# Altium schematic sheet ICE40UP5K base design.SchDoc
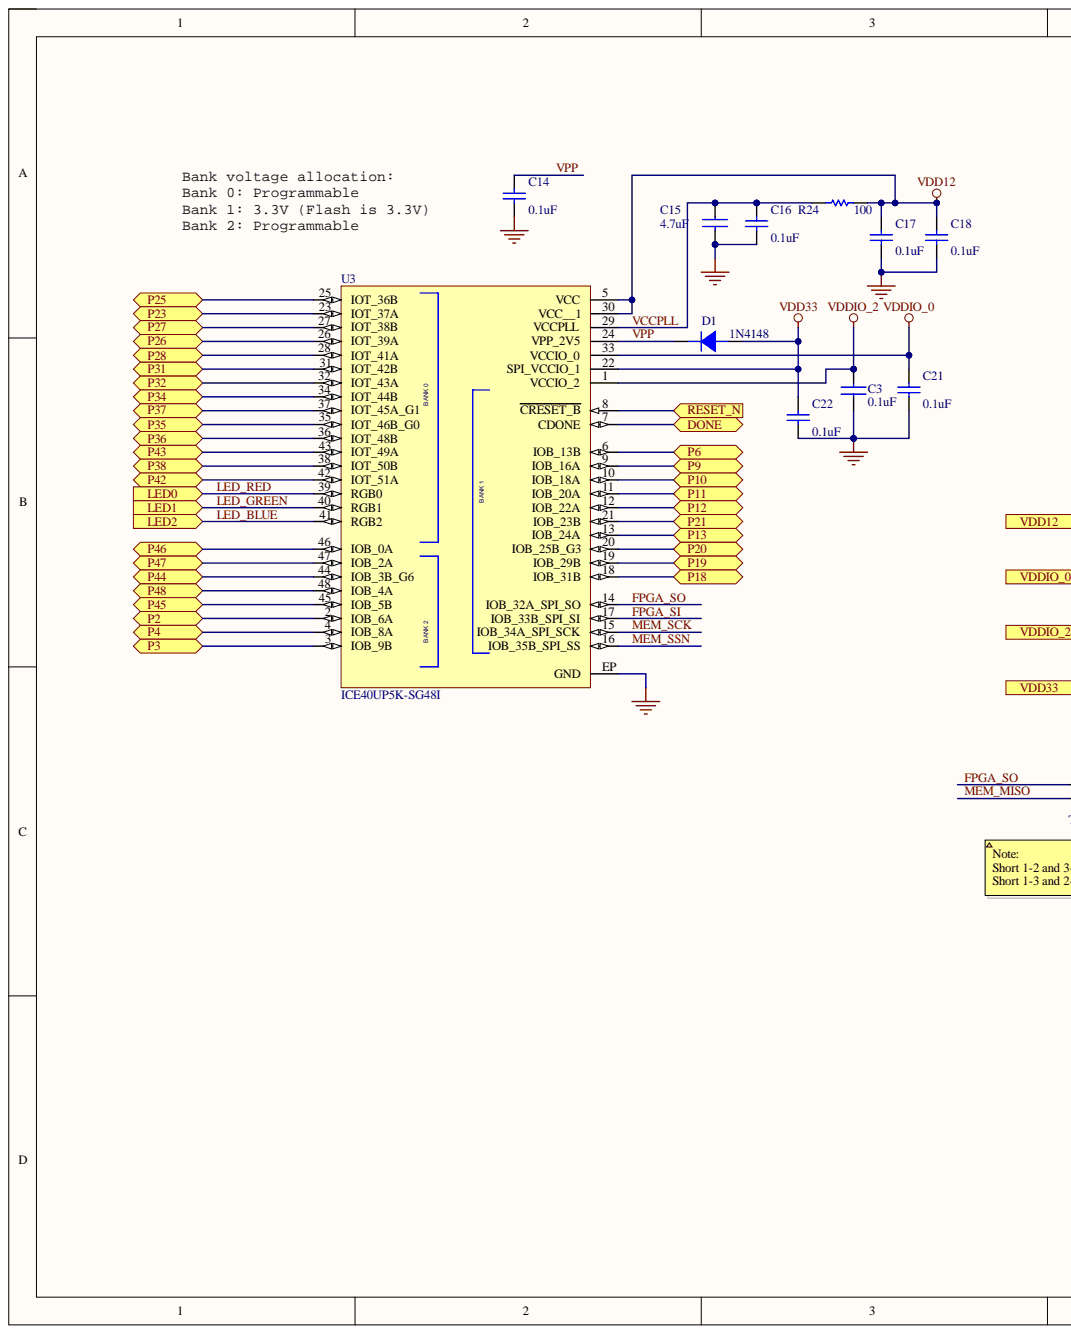
[diagram p0: ICE40UP5K base design.SchDoc, left]
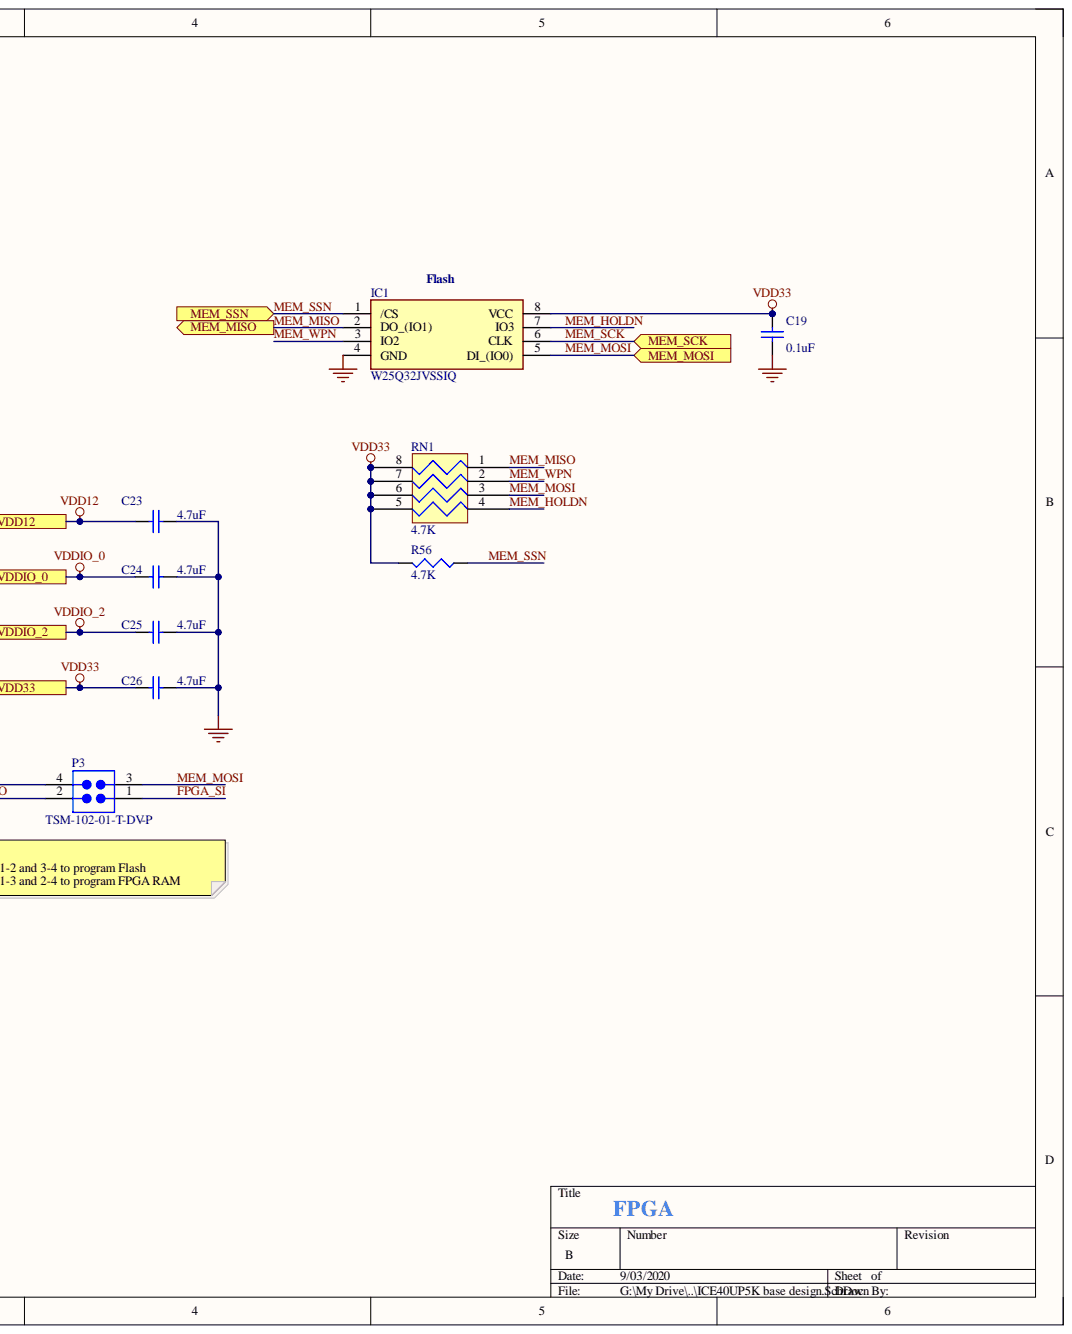
[diagram p1: ICE40UP5K base design.SchDoc, right]

## Parts
C3  0.1uF  [0402]
C14  0.1uF  [C0603]
C15  4.7uF  [C0603]
C16  0.1uF  [C0603]
C17  0.1uF  [C0603]
C18  0.1uF  [C0603]
C19  0.1uF  [C0603]
C21  0.1uF  [C0603]
C22  0.1uF  [C0603]
C23  4.7uF  [C0603]
C24  4.7uF  [C0603]
C25  4.7uF  [C0603]
C26  4.7uF  [C0603]
D1  1N4148  [SOD-523F]
IC1  W25Q32JVSSIQ  [SOIC-8-200MIL]
P3  TSM-102-01-T-DV-P  [TSM-102-01-T-DV-P-TR]
R24  100  [R0603]
R56  4.7K  [R0603]
RN1  4.7K  [RESCAXS50P100X200X45-8NA]
U3  ICE40UP5K-SG48I  [QFN-48-1EP_7x7mm_P0.5mm_EP5.6x5.6mm]

## Ports
DONE, LED0, LED1, LED2, MEM_MISO, MEM_MOSI, MEM_SCK, MEM_SSN, P2, P3, P4, P6, P9, P10, P11, P12, P13, P18, P19, P20, P21, P23, P25, P26, P27, P28, P31, P32, P34, P35, P36, P37, P38, P42, P43, P44, P45, P46, P47, P48, RESET_N, VDD12, VDD33, VDDIO_0, VDDIO_2

## Nets
GND: C3.1 C14.2 C15.2 C16.2 C17.2 C18.2 C19.2 C21.2 C22.2 C23.1 C24.1 C25.1 C26.1 IC1.4(GND) U3.EP(GND)
DONE: U3.7(CDONE) ; port DONE
FPGA_SI: P3.1 U3.17(IOB_33B_SPI_SI)
FPGA_SO: P3.4 U3.14(IOB_32A_SPI_SO)
LED_BLUE: U3.41(RGB2) ; port LED2
LED_GREEN: U3.40(RGB1) ; port LED1
LED_RED: U3.39(RGB0) ; port LED0
MEM_HOLDN: IC1.7(IO3) RN1.4
MEM_MISO: IC1.2(DO_(IO1)) P3.2 RN1.1 ; port MEM_MISO
MEM_MOSI: IC1.5(DI_(IO0)) P3.3 RN1.3 ; port MEM_MOSI
MEM_SCK: IC1.6(CLK) U3.15(IOB_34A_SPI_SCK) ; port MEM_SCK
MEM_SSN: IC1.1(/CS) R56.1 U3.16(IOB_35B_SPI_SS) ; port MEM_SSN
MEM_WPN: IC1.3(IO2) RN1.2
P2: U3.2(IOB_6A) ; port P2
P3: U3.3(IOB_9B) ; port P3
P4: U3.4(IOB_8A) ; port P4
P6: U3.6(IOB_13B) ; port P6
P9: U3.9(IOB_16A) ; port P9
P10: U3.10(IOB_18A) ; port P10
P11: U3.11(IOB_20A) ; port P11
P12: U3.12(IOB_22A) ; port P12
P13: U3.13(IOB_24A) ; port P13
P18: U3.18(IOB_31B) ; port P18
P19: U3.19(IOB_29B) ; port P19
P20: U3.20(IOB_25B_G3) ; port P20
P21: U3.21(IOB_23B) ; port P21
P23: U3.23(IOT_37A) ; port P23
P25: U3.25(IOT_36B) ; port P25
P26: U3.26(IOT_39A) ; port P26
P27: U3.27(IOT_38B) ; port P27
P28: U3.28(IOT_41A) ; port P28
P31: U3.31(IOT_42B) ; port P31
P32: U3.32(IOT_43A) ; port P32
P34: U3.34(IOT_44B) ; port P34
P35: U3.35(IOT_46B_G0) ; port P35
P36: U3.36(IOT_48B) ; port P36
P37: U3.37(IOT_45A_G1) ; port P37
P38: U3.38(IOT_50B) ; port P38
P42: U3.42(IOT_51A) ; port P42
P43: U3.43(IOT_49A) ; port P43
P44: U3.44(IOB_3B_G6) ; port P44
P45: U3.45(IOB_5B) ; port P45
P46: U3.46(IOB_0A) ; port P46
P47: U3.47(IOB_2A) ; port P47
P48: U3.48(IOB_4A) ; port P48
RESET_N: U3.8(CRESET_B) ; port RESET_N
VCCPLL: C15.1 C16.1 R24.1 U3.29(VCCPLL)
VDD12: C17.1 C18.1 C23.2 R24.2 U3.5(VCC) U3.30(VCC__1) ; port VDD12
VDD33: C19.1 C22.1 C26.2 D1.A IC1.8(VCC) R56.2 RN1.5 RN1.6 RN1.7 RN1.8 U3.22(SPI_VCCIO_1) ; port VDD33
VDDIO_0: C21.1 C24.2 U3.33(VCCIO_0) ; port VDDIO_0
VDDIO_2: C3.2 C25.2 U3.1(VCCIO_2) ; port VDDIO_2
VPP: C14.1 D1.C U3.24(VPP_2V5)

## Library data of the parts
C3 = CAP NP: CAP CER 0.1UF 6.3V X5R 0402; Component Kind: Standard
C14 C16-C19 C21 C22 = Cap_100nF_X7R_10%_0402: CAP CER 0.1UF 6.3V X5R; Component Kind: Standard; Library Name: MCD_Temp_LBE.SchLib
C15 = CAP NP: CAP CER 4.7UF 6.3V; Component Kind: Standard
C23-C26 = Cap_1uF_X5R_10%_6V3_0402: CAP CER 4.7UF 6.3V; Component Kind: Standard; Library Name: MCD_Temp_LBE.SchLib
D1 = DIODE: Diode 1N4148, SOD-523F; Component Kind: Standard; Library Name: TINYMODULE_Library.SchLib
IC1 = W25Q32JVSSIQ: Integrated Circuit; Manufacturer_Name: Winbond; Component Kind: Standard; Datasheet Link: https://www.winbond.com/resource-files/w25q32jv%20spi%20revc%2008302016.pdf; Height: 2.16mm; Manufacturer_Part_Number: W25Q32JVSSIQ; Mouser Part Number: 454-W25Q32JVSSIQ; Mouser Price/Stock: https://www.mouser.com/Search/Refine.aspx?Keyword=454-W25Q32JVSSIQ
P3 = SMTC-TSM-102-01-X-DV-P: 0.025" SQ Post Header, Surface Mount, Vertical, -55 to 105 degC, 2.54 mm Pitch, 4-Pin, Male, RoHS; Manufacturer: Samtec; Pitch: 2.54 mm; Component Kind: Standard; ComponentLink1Description: Manufacturer URL; ComponentLink1URL: http://www.samtec.com; ComponentLink2Description: Datasheet; ComponentLink2URL: http://www.samtec.com/documents/webfiles/pdf/TSM.PDF; ComponentLink3Description: Package Specification; ComponentLink3URL: http://www.samtec.com/documents/webfiles/cpdf/TSM-1XX-XX-XXX-XX-X-XXX-X-MKT.pdf; DatasheetVersion: F-214; Mounting Technology: Surface Mount; Orientation: Vertical; PackageDescription: Double Row Gullwing ASM; PackageReference: TSM-102-01-X-DV-P; PackageVersion: DJ, 4/1991; PartNumber: TSM-102-01-T-DV-P; RoHS: True; Temperature Range: -55 to 105 degC; Library Name: Vilkon.IntLib
R24 = Res_100_1%_0402: RES 100 OHM 5%; Component Kind: Standard; Library Name: MCD_Temp_LBE.SchLib
R56 = R_SH: RESISTOR 4.7K OHM 1%; Component Kind: Standard
RN1 = EXB28V: 4.7K Resistor pack 2010 (0804 imperial) convex; Component Kind: Standard; Library Name: main.SchLib; Package: 0804
U3 = ICE40UP5K-SG48I: iCE40 UltraPlus 5280 LUTs 39 I/O 1.2 V Surface Mount FOPGA - QFN-48; MF: Lattice Semiconductor; Component Kind: Standard; MP: ICE40UP5K-SG48I; Package: QFN-48 Lattice Semiconductor; Library Name: TINYMODULE.SchLib
